# Altium schematic sheet Bwallet.SchDoc
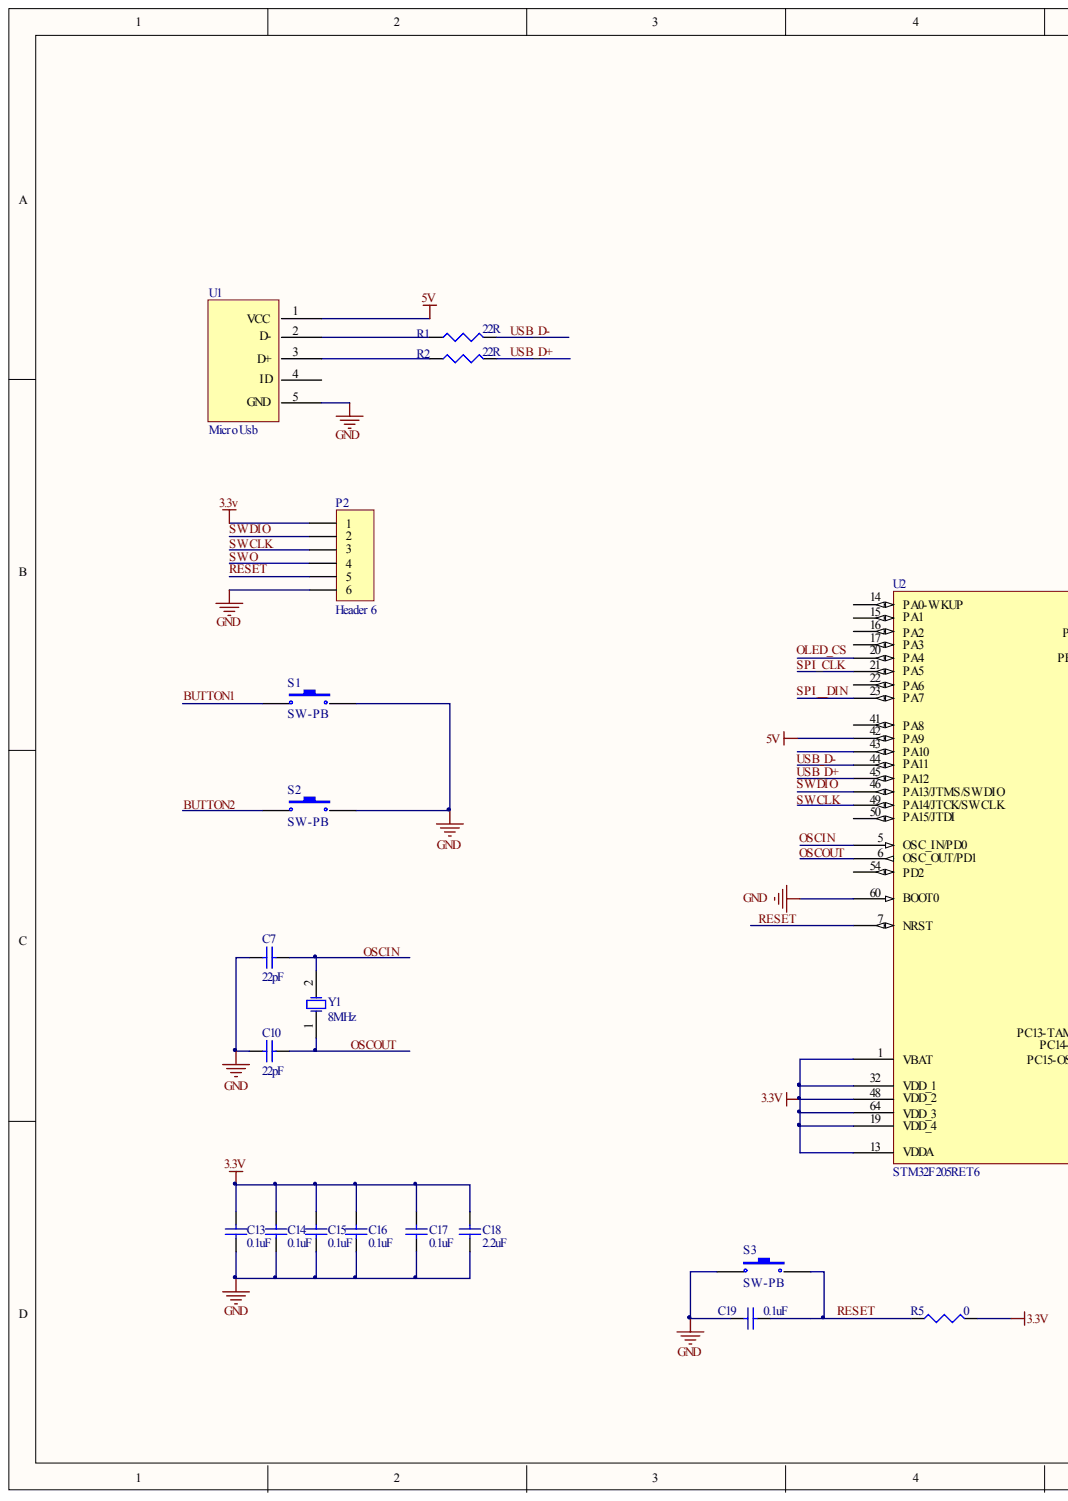
[diagram p0: Bwallet.SchDoc, left]
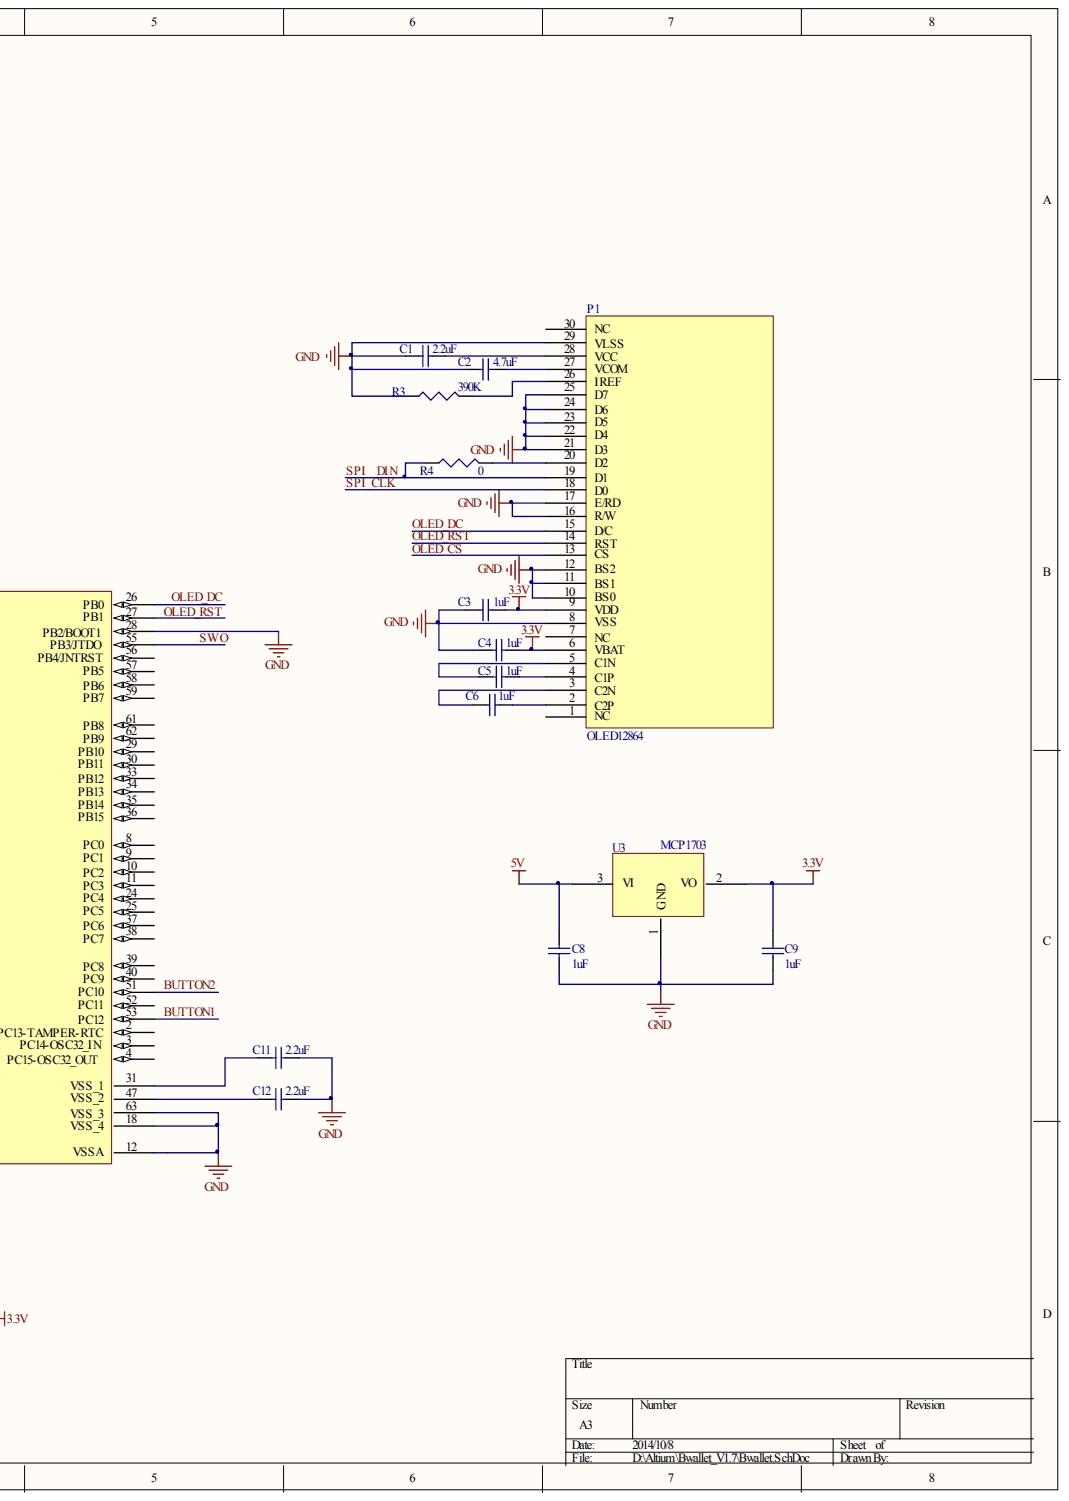
[diagram p1: Bwallet.SchDoc, right]

## Parts
C1  value 2.2uF  (hidden comment: 50V)
C2  value 4.7uF  (hidden comment: 50V)
C3  value 1uF  (hidden comment: 50V)
C4  value 1uF  (hidden comment: 50V)
C5  value 1uF  (hidden comment: 50V)
C6  value 1uF  (hidden comment: 50V)
C7  value 22pF  (hidden comment: 50V)
C8  value 1uF  (hidden comment: 50V)
C9  value 1uF  (hidden comment: 50V)
C10  value 22pF  (hidden comment: 50V)
C11  value 2.2uF  (hidden comment: 50V)
C12  value 2.2uF  (hidden comment: 50V)
C13  value 0.1uF  (hidden comment: 50V)
C14  value 0.1uF  (hidden comment: 50V)
C15  value 0.1uF  (hidden comment: 50V)
C16  value 0.1uF  (hidden comment: 50V)
C17  value 0.1uF  (hidden comment: 50V)
C18  value 2.2uF  (hidden comment: 50V)
C19  value 0.1uF  (hidden comment: 50V)
P1  OLED12864
P2  Header 6
R1  value 22R  (hidden comment: 0.1W)
R2  value 22R  (hidden comment: 0.1W)
R3  value 390K  (hidden comment: 0.1W)
R4  value 0  (hidden comment: 0.1W)
R5  value 0  (hidden comment: 0.1W)
S1  SW-PB
S2  SW-PB
S3  SW-PB
U1  Micro Usb
U2  STM32F205RET6
U3  MCP1703
Y1  8MHz

## Nets
3.3V: C3.1 C4.2 C9.2 C13.2 C14.2 C15.2 C16.2 C17.2 C18.2 P1.6(VBAT) P1.9(VDD) P2.1 R5.2 U2.1(VBAT) U2.13(VDDA) U2.19(VDD_4) U2.32(VDD_1) U2.48(VDD_2) U2.64(VDD_3) U3.2
5V: C8.2 U1.1(VCC) U2.42(PA9) U3.3
GND: C1.2 C2.2 C3.2 C4.1 C7.1 C8.1 C9.1 C10.1 C11.1 C12.1 C13.1 C14.1 C15.1 C16.1 C17.1 C18.1 C19.1 P1.8(VSS) P1.10(BS0) P1.11(BS1) P1.12(BS2) P1.16(R/W) P1.17(E/RD) P1.21(D3) P1.22(D4) P1.23(D5) P1.24(D6) P1.25(D7) P1.29(VLSS) P2.6 R3.1 S1.2 S2.2 S3.1 U1.5(GND) U2.12(VSSA) U2.18(VSS_4) U2.28(PB2/BOOT1) U2.60(BOOT0) U2.63(VSS_3) U3.1
BUTTON1: S1.1 U2.53(PC12)
BUTTON2: S2.1 U2.51(PC10)
OLED_CS: P1.13(CS) U2.20(PA4)
OLED_DC: P1.15(D/C) U2.26(PB0)
OLED_RST: P1.14(RST) U2.27(PB1)
OSCIN: C7.2 U2.5(OSC_IN/PD0) Y1.2
OSCOUT: C10.2 U2.6(OSC_OUT/PD1) Y1.1
RESET: C19.2 P2.5 R5.1 S3.2 U2.7(NRST)
SPI__DIN: P1.19(D1) R4.1 U2.23(PA7)
SPI_CLK: P1.18(D0) U2.21(PA5)
SWCLK: P2.3 U2.49(PA14/JTCK/SWCLK)
SWDIO: P2.2 U2.46(PA13/JTMS/SWDIO)
SWO: P2.4 U2.55(PB3/JTDO)
USB_D+: R2.2 U2.45(PA12)
USB_D-: R1.2 U2.44(PA11)
NetC1_1: C1.1 P1.28(VCC)
NetC2_1: C2.1 P1.27(VCOM)
NetC5_1: C5.1 P1.5(C1N)
NetC5_2: C5.2 P1.4(C1P)
NetC6_1: C6.1 P1.3(C2N)
NetC6_2: C6.2 P1.2(C2P)
NetC11_2: C11.2 U2.31(VSS_1)
NetC12_2: C12.2 U2.47(VSS_2)
NetP1_20: P1.20(D2) R4.2
NetP1_26: P1.26(IREF) R3.2
NetR1_1: R1.1 U1.2(D-)
NetR2_1: R2.1 U1.3(D+)

Not connected: P1 1(NC) 7(NC) 30(NC); U1 4(ID); U2 2(PC13-TAMPER-RTC) 3(PC14-OSC32_IN) 4(PC15-OSC32_OUT) 8(PC0) 9(PC1) 10(PC2) 11(PC3) 14(PA0-WKUP) 15(PA1) 16(PA2) 17(PA3) 22(PA6) 24(PC4) 25(PC5) 29(PB10) 30(PB11) 33(PB12) 34(PB13) 35(PB14) 36(PB15) 37(PC6) 38(PC7) 39(PC8) 40(PC9) 41(PA8) 43(PA10) 50(PA15/JTDI) 52(PC11) 54(PD2) 56(PB4/JNTRST) 57(PB5) 58(PB6) 59(PB7) 61(PB8) 62(PB9)

## Library data of the parts
C1-C19 P1 P2 R1-R5 S1-S3 U1-U3 Y1 = (no symbol name)
  C1-C19: Published: 8-Jun-2000; LatestRevisionDate: 29-May-2009; LatestRevisionNote: IPC-7351 Footprint Added.; PackageReference: C1206; PackageDescription: Chip Capacitor
  P2: LatestRevisionDate: 17-Jul-2002; LatestRevisionNote: Re-released for DXP Platform.
  R1-R5: Published: 8-Jun-2000; LatestRevisionDate: 29-May-2009; LatestRevisionNote: IPC-7351 Footprint Added.; PackageReference: J1-0603; PackageDescription: Chip Resistor
  S1-S3: Published: 24-Mar-1999; LatestRevisionDate: 17-Jul-2002; LatestRevisionNote: Re-released for DXP Platform.; PackageReference: SPST-2; PackageDescription: Switch; 2 Leads
  U2: Published: 30-May-2008; DatasheetVersion: rev6, Mar-2008; PackageVersion: Mar-2008; PackageReference: LQFP64; PackageDescription: 64-Pin Low-Profile Quad Flat Pack, 10 x 10 mm Body, 0.5 mm Pitch (LQFP64); ComponentLink1Description: Manufacturer Link; ComponentLink1URL: www.st.com/stm32; ComponentLink2Description: Datasheet; ComponentLink2URL: http://www.st.com/stonline/products/literature/ds/13586.pdf
  Y1: Published: 8-Jun-2000; LatestRevisionDate: 17-Jul-2002; LatestRevisionNote: Re-released for DXP Platform.; PackageReference: R38; PackageDescription: Crystal, Thru-Hole; 2 Leads; Body 3.1 x 8.2 mm (Dia.xH)
  C1-C19 P2 R1-R5 S1-S3 U2 Y1: Publisher: Altium Limited
